# Altium schematic sheet 接线图.SchDoc
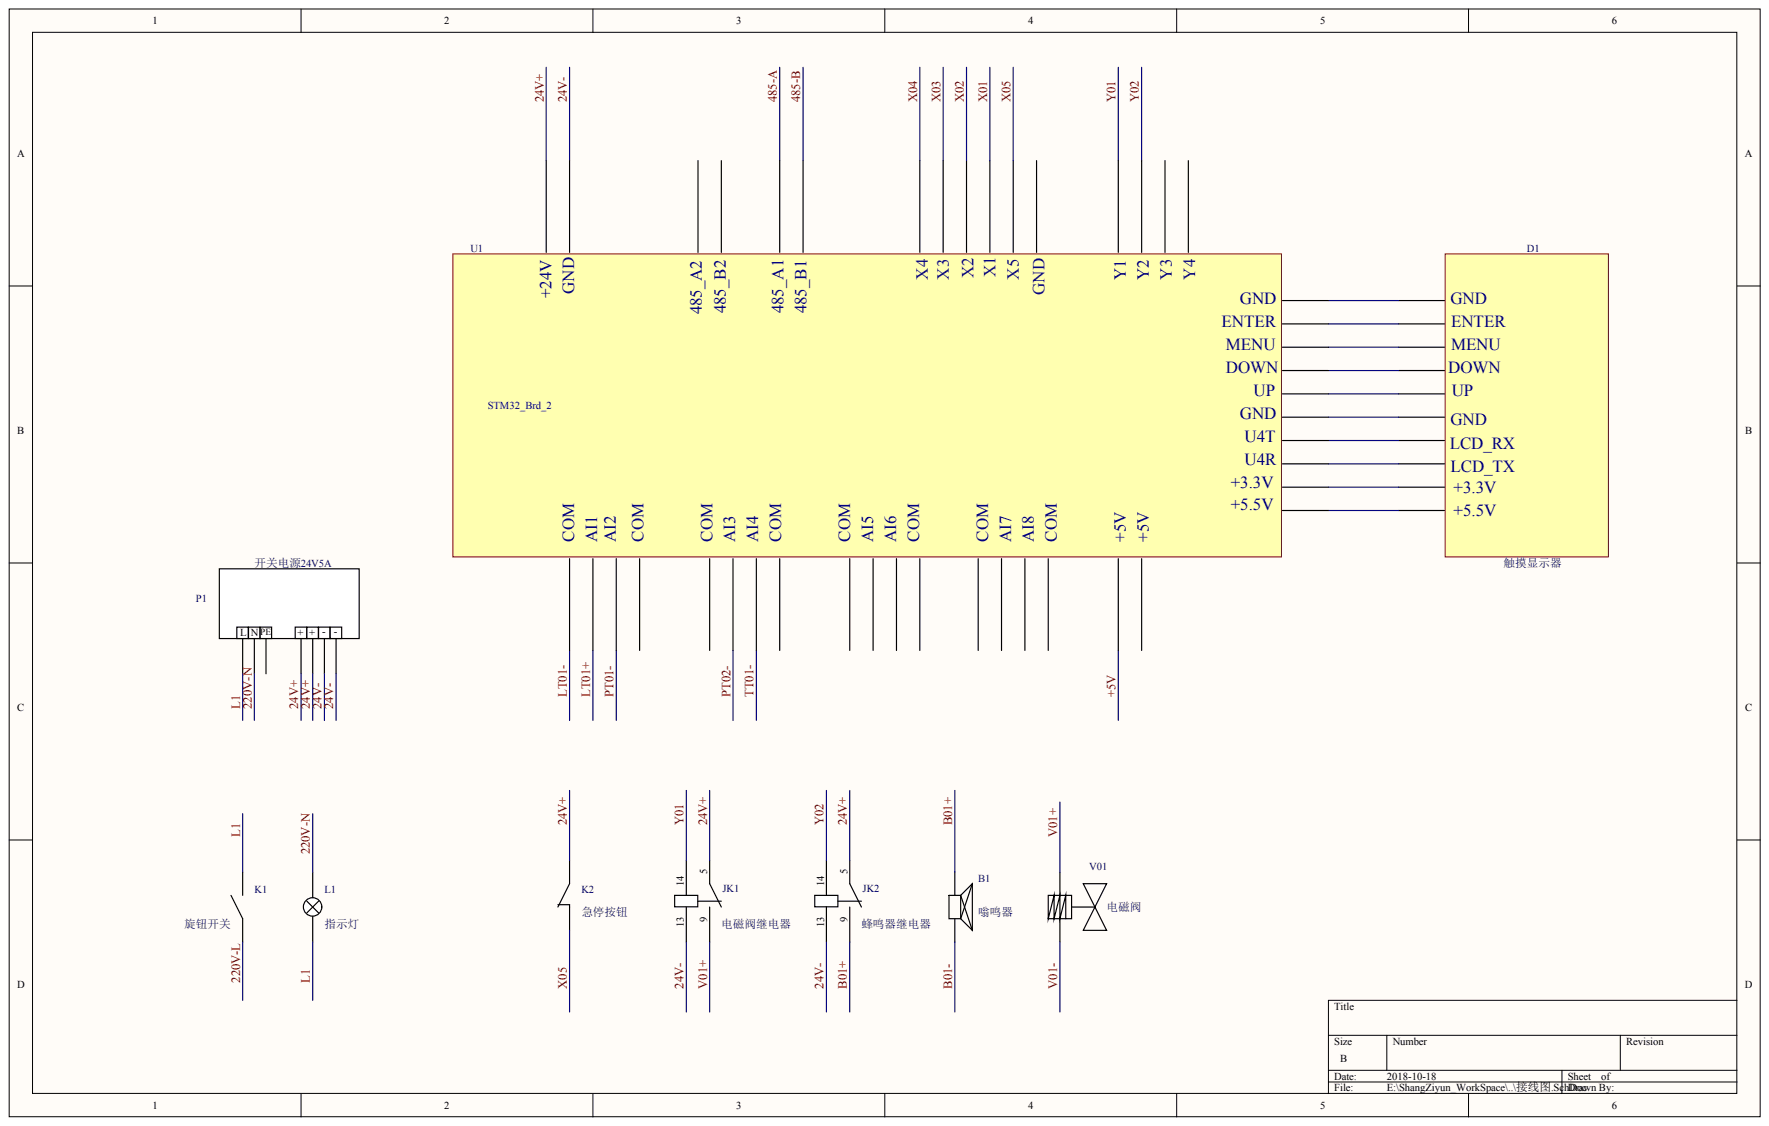
[diagram p0: 接线图.SchDoc]

## Parts
B1  嗡鸣器
D1  触摸显示器
JK1  电磁阀继电器
JK2  蜂鸣器继电器
K1  旋钮开关
K2  急停按钮
L1  指示灯
P1  开关电源24V5A
U1  STM32_Brd_2
V01  电磁阀

## Nets
24V+: JK1.5 JK2.5 K2.2 P1.4(+) P1.6(+)
24V-: JK1.13 JK2.13 P1.5(-) P1.7(-) U1.3(GND)
220V-L: K1.2
220V-N: L1.2 P1.2(N)
485-A: U1.43(485_A1)
485-B: U1.44(485_B1)
+5V: U1.31(+5V)
B01+: B1.1 JK2.9
B01-: B1.2
L1: K1.1 L1.1 P1.1(L)
LT01+: U1.16(AI1)
LT01-: U1.15(COM)
PT01-: U1.17(AI2)
PT02-: U1.20(AI3)
TT01-: U1.21(AI4)
V01+: JK1.9 V01.2
V01-: V01.1
X01: U1.10(X1)
X02: U1.9(X2)
X03: U1.8(X3)
X04: U1.7(X4)
X05: K2.1 U1.6
Y01: JK1.14 U1.11(Y1)
Y02: JK2.14 U1.12(Y2)
NetD1_33: D1.33(GND) U1.33(GND)
NetD1_34: D1.34(ENTER) U1.34(ENTER)
NetD1_35: D1.35(MENU) U1.35(MENU)
NetD1_36: D1.36(DOWN) U1.36(DOWN)
NetD1_37: D1.37(UP) U1.37(UP)
NetD1_38: D1.38(GND) U1.38(GND)
NetD1_39: D1.39(LCD_RX) U1.39(U4T)
NetD1_40: D1.40(LCD_TX) U1.40(U4R)
NetD1_41: D1.41(+3.3V) U1.41(+3.3V)
NetD1_42: D1.42(+5.5V) U1.42(+5.5V)

Not connected: P1 3(PE); U1 2(+24V) 4(485_A2) 5(485_B2) 13(Y3) 14(Y4) 18(COM) 19(COM) 22(COM) 23(COM) 24(AI5) 25(AI6) 26(COM) 27(COM) 28(AI7) 29(AI8) 30(COM) 32(+5V)

## Library data of the parts
JK1 JK2 = 中间继电器-常开触点: Component Kind: Standard; Library Name: 电气元件.SchLib
K1 = 常开触点: Component Kind: Standard; Library Name: 电气元件.SchLib
K2 = 常闭触点: Component Kind: Standard; Library Name: 电气元件.SchLib
B1 = 嗡鸣器: Component Kind: Standard; Library Name: 电气元件.SchLib
D1 = 触摸显示器: Component Kind: Standard; Library Name: 电气元件.SchLib
L1 = 指示灯: Component Kind: Standard; Library Name: 电气元件.SchLib
P1 = 开关电源24V5A: Component Kind: Standard; Library Name: 电气元件.SchLib
U1 = STM32_Brd_2: Component Kind: Standard; Library Name: PLC.SchLib
V01 = 电磁阀: Component Kind: Standard; Library Name: 电气元件.SchLib
